# Altium schematic sheet ESDREV5.SchDoc(ESDREV5)
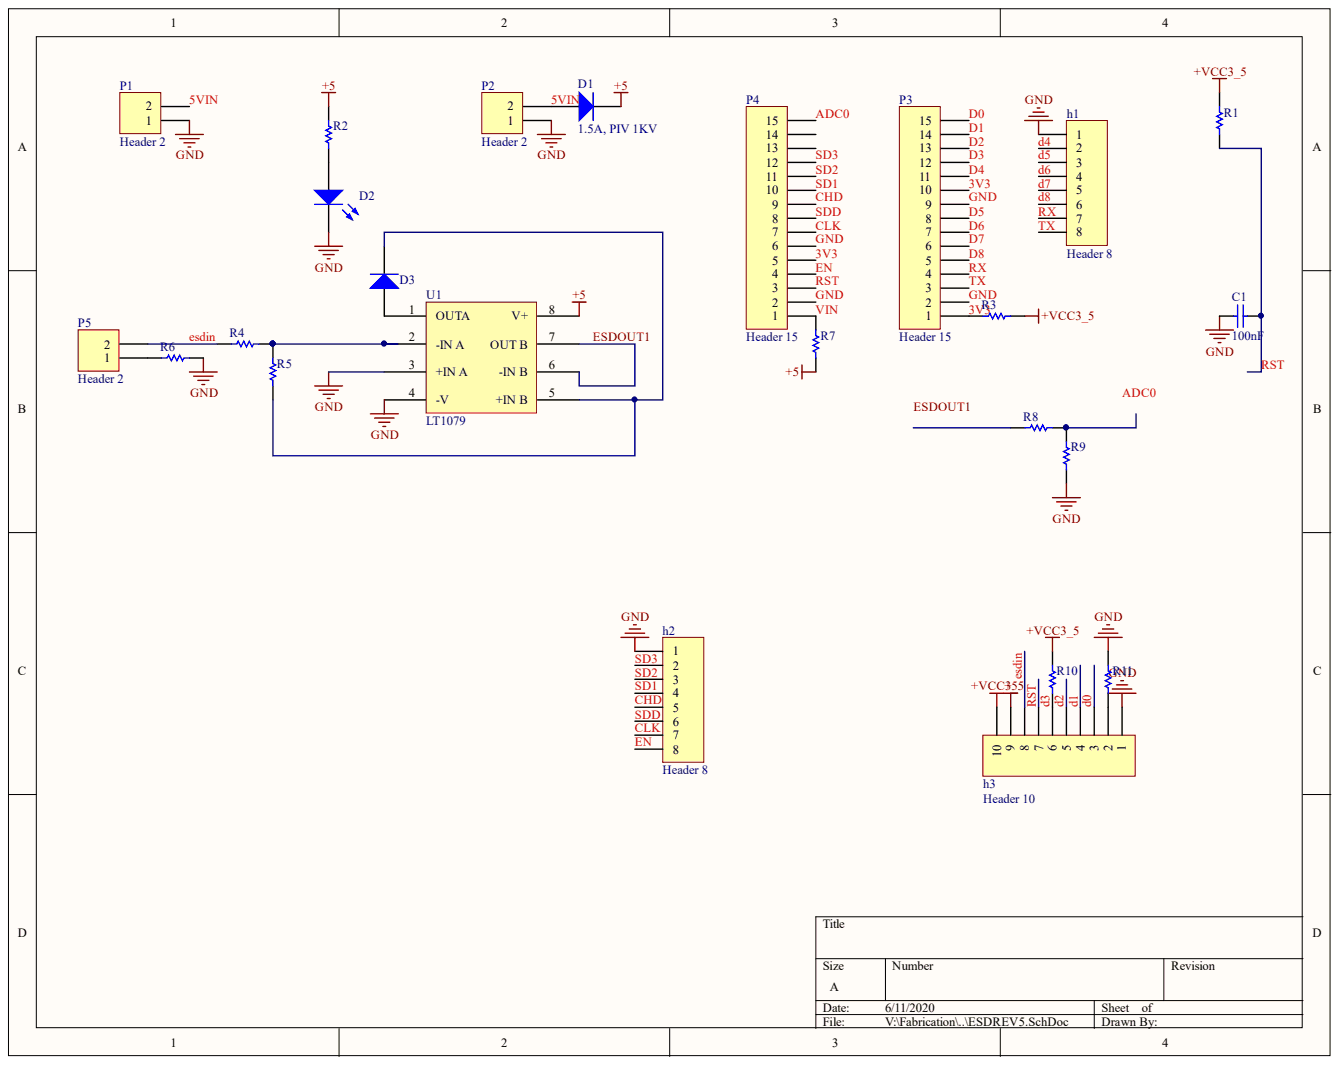
[diagram p0: ESDREV5.SchDoc]

## Parts
C1  100nF
D1  1.5A, PIV 1KV
D2  LED3  (value not drawn)
D3  Diode 1N4448  (value not drawn)
h1  Header 8
h2  Header 8
h3  Header 10
P1  Header 2
P2  Header 2
P3  Header 15
P4  Header 15
P5  Header 2
R1  (no value)
R2  R
R3  (no value)
R4  R
R5  R
R6  (no value)
R7  (no value)
R8  (no value)
R9  (no value)
R10  (no value)
R11  (no value)
U1  LT1079

## Nets
+5: D1.K h3.9 R2.2 R7.2 U1.8(V+)
+VCC3_5: h3.10 R1.2 R3.1 R10.2
3V3: P3.1 P3.10 P4.5 R3.2
5VIN: D1.A P1.1 P2.1
ADC0: P4.15
CHD: h2.5 P4.9
CLK: h2.7 P4.7
D0: h3.3 P3.15
D1: h3.4 P3.14
D2: h3.5 P3.13
D3: h3.6 P3.12 R10.1
D4: h1.2 P3.11
D5: h1.3 P3.8
D6: h1.4 P3.7
D7: h1.5 P3.6
D8: h1.6 P3.5
EN: h2.8 P4.4
ESDIN: h3.8 P5.2 R4.1
ESDOUT1: U1.6(-IN_B) U1.7(OUT_B)
GND: C1.1 D2.K h1.1 h2.1 h3.1 P1.2 P2.2 P3.2 P3.9 P4.2 P4.6 R6.1 R9.1 R11.2 U1.3(+IN_A) U1.4(-V)
RST: C1.2 h3.7 P4.3 R1.1
RX: h1.7 P3.4
SD1: h2.4 P4.10
SD2: h2.3 P4.11
SD3: h2.2 P4.12
SDD: h2.6 P4.8
TX: h1.8 P3.3
VIN: P4.1 R7.1
NetD2_A: D2.A R2.1
NetD3_1: D3.1 U1.1(OUTA)
NetD3_2: D3.2 R5.1 U1.5(+IN_B)
Neth3_2: h3.2 R11.1
NetP5_1: P5.1 R6.2
NetR4_2: R4.2 R5.2 U1.2(-IN_A)
NetR8_1: R8.1 R9.2

Not connected: P4 13 14; R8 2

## Library data of the parts
C1 = (no symbol name): SMLPARTNO: BCS129-104; Mfr Name: KEMET; Units of Voltage: V dc; Value(Farad): 100U; Voltage Rating: 16; Units of Capacitance: nF; Tolerance (%): +/-10%; Dielectric: X7R; Sub Category: Ceramic; Capacitance: 100; Published: 8-Jun-2000; LatestRevisionDate: 17-Jul-2002; LatestRevisionNote: Re-released for DXP Platform.; PackageReference: C1206; Life Cycle: Production; Package: 0603; Application: Filtering; Coupling & Decoupling; Mounting Type: SMD; Publisher: Altium Limited
D1 = (no symbol name): SMLPARTNO: BDS334-001; Mfr Name: VISHAY; Units of Voltage: kV; Secure Use Status: Authorization Required; Units of Current: A; Peak Inverse Voltage: 1; Average Forward Current: 1.5; Sub Category: High Voltage; Published: 8-Jun-2000; LatestRevisionDate: 17-Jul-2002; LatestRevisionNote: Re-released for DXP Platform.; PackageDocument: Sep-1996; PackageReference: SMC; Code_JEDEC: DO-214-AB; Life Cycle: Production; Mounting Type: SMD; HelpURL: http://dataudr.udp.sml.com/DataSheet/EX0551-218-R00.pdf; Package: DO-214AA; Publisher: Altium Limited
R1 R6 = (no symbol name): SMLPARTNO: BRS133-472; Mfr Name: VISHAY; Sub Category: Thick Film; Resistance: 4.7k; Tempco1: 0.0002; Unit Of Resistance: k Ohm; Value(ohm): 4.7; Tolerance: +/-5%; Power Rating: 1/10W; Life Cycle: Obsolete; Package: 0603; Mounting Type: SMD
R2 = (no symbol name): SMLPARTNO: BRS133-472; Mfr Name: VISHAY; Sub Category: Thick Film; Resistance: 47; Tempco1: 0.0002; Unit Of Resistance: k Ohm; Value(ohm): 4.7k; Tolerance: +/-5%; Power Rating: 1/10W; Life Cycle: Obsolete; Package: 0603; Mounting Type: SMD
R3 R8 = (no symbol name): SMLPARTNO: BRS121-152; Mfr Name: VISHAY; Sub Category: Thick Film; Resistance: 1.5; Tempco1: 0.0002; Unit Of Resistance: ohm; Value(ohm): 1.5 K; Tolerance: +/-5%; Power Rating: 1/10W; Life Cycle: Obsolete; Package: 0603; Mounting Type: SMD
R4 = (no symbol name): SMLPARTNO: BRS121-224; Mfr Name: VISHAY; Sub Category: Thick Film; Resistance: 220; Tempco1: 0.0002; Unit Of Resistance: k Ohm; Tolerance: +/-5%; Power Rating: 1/10W; Life Cycle: Obsolete; Package: 0603; Mounting Type: SMD; Value(ohm): 220
R5 = (no symbol name): SMLPARTNO: BRS121-224; Mfr Name: VISHAY; Sub Category: Thick Film; Resistance: 220; Tempco1: 0.0002; Unit Of Resistance: k Ohm; Value(ohm): 200k; Tolerance: +/-5%; Power Rating: 1/10W; Life Cycle: Obsolete; Package: 0603; Mounting Type: SMD
R7 = (no symbol name): SMLPARTNO: BRS133-0; Mfr Name: VISHAY; Sub Category: Thick Film; Resistance: 4.7; Tempco1: 0.0002; Unit Of Resistance: ohm; Value(ohm): 0; Tolerance: +/-5%; Power Rating: 1/10W; Life Cycle: Obsolete; Package: 0603; Mounting Type: SMD
R9 = (no symbol name): SMLPARTNO: BRS005-103; Mfr Name: VISHAY; Sub Category: Thick Film; Resistance: 10; Tempco1: 0.0002; Unit Of Resistance: ohm; Value(ohm): 10 K; Tolerance: +/-5%; Power Rating: 1/10W; Life Cycle: Obsolete; Package: 0603; Mounting Type: SMD
R10 R11 = (no symbol name): SMLPARTNO: BRS133-471; Mfr Name: VISHAY; Sub Category: Thick Film; Resistance: 4.7; Tempco1: 0.0002; Unit Of Resistance: ohm; Value(ohm): 470; Tolerance: +/-5%; Power Rating: 1/10W; Life Cycle: Obsolete; Package: 0603; Mounting Type: SMD
D2 D3 h1-h3 P1-P5 U1 = (no symbol name)
  D2: Published: 8-Jun-2000; LatestRevisionDate: 17-Dec-2003; LatestRevisionNote: PCB Footprint replaced.; DatasheetVersion: 16-Apr-2002; PackageVersion: 16-Apr-2002; PackageReference: 3.5X2.8X1.9; PackageDescription: SM LED; 2 C-Bend Leads; Body 3.5 x 2.8 x 1.9 mm, inc leads (LxWxH typ)
  D3: Published: 8-Jun-2000; LatestRevisionDate: 17-Jul-2002; LatestRevisionNote: Re-released for DXP Platform.; DatasheetVersion: 1997; PackageReference: DO-35; PackageDescription: Diode, Axial; Body 3.8 x 1.9 mm (LxDia. max), Lead Dia. 0.53 mm (max); Code_JEDEC: DO-204-AH; ComponentLink1Description: Manufacturer Link; ComponentLink1URL: http://www.fairchildsemi.com/; ComponentLink2Description: Datasheet; ComponentLink2URL: http://www.fairchildsemi.com/ds/1N%2F1N4448.pdf
  h1-h3 P1-P5: LatestRevisionDate: 17-Jul-2002; LatestRevisionNote: Re-released for DXP Platform.
  D2 D3 h1-h3 P1-P5: Publisher: Altium Limited
